# Altium schematic sheet interfaces_module.SchDoc
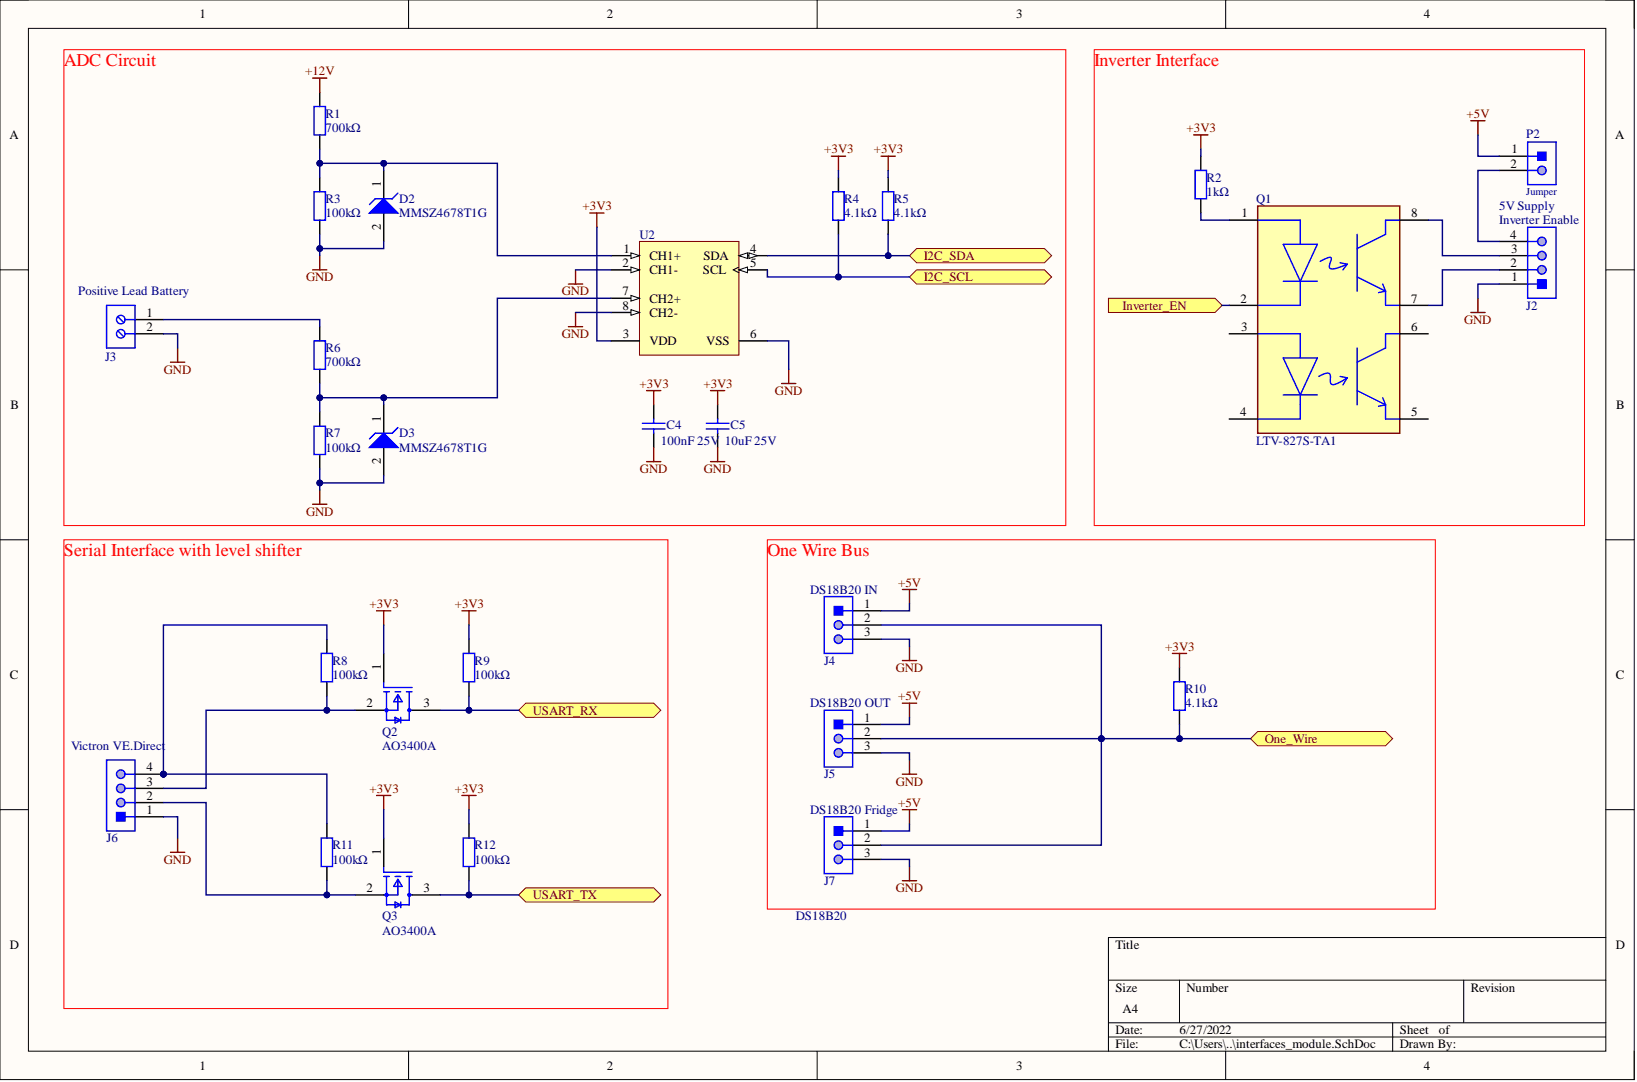
[diagram p0: interfaces_module.SchDoc]

## Parts
C4  100nF 25V  [CAPC2013X88X50ML20T25]
C5  10uF 25V  [CAPC2013X88X50ML20T25]
D2  MMSZ4678T1G  [ONSC-SOD-123-2-425-04_V]
D3  MMSZ4678T1G  [ONSC-SOD-123-2-425-04_V]
J2  JST-S4B-PH-SM4-TB-3_V  [JST-S4B-PH-SM4-TB-3_V]  (value not drawn)
J3  282836-2  [TECO-282836-2_V]  (value not drawn)
J4  JST-S3B-PH-SM4-TB-3_V  [JST-S3B-PH-SM4-TB-3_V]  (value not drawn)
J5  JST-S3B-PH-SM4-TB-3_V  [JST-S3B-PH-SM4-TB-3_V]  (value not drawn)
J6  JST-S4B-PH-SM4-TB-3_V  [JST-S4B-PH-SM4-TB-3_V]  (value not drawn)
J7  JST-S3B-PH-SM4-TB-3_V  [JST-S3B-PH-SM4-TB-3_V]  (value not drawn)
P2  Jumper  [61300211121]
Q1  LTV-827S-TA1  [FP-LTV-827S-TA1-MFG]
Q2  AO3400A  [SOT-23]
Q3  AO3400A  [SOT-23]
R1  700kΩ  [RESC2013X50X30ML20T20]
R2  1kΩ  [RESC2013X50X30ML20T20]
R3  100kΩ  [RESC2013X50X30ML20T20]
R4  4.1kΩ  [RESC2013X50X30ML20T20]
R5  4.1kΩ  [RESC2013X50X30ML20T20]
R6  700kΩ  [RESC2013X50X30ML20T20]
R7  100kΩ  [RESC2013X50X30ML20T20]
R8  100kΩ  [RESC2013X50X30ML20T20]
R9  100kΩ  [RESC2013X50X30ML20T20]
R10  4.1kΩ  [RESC2013X50X30ML20T20]
R11  100kΩ  [RESC2013X50X30ML20T20]
R12  100kΩ  [RESC2013X50X30ML20T20]
U2  (no value)  [SOIC-SN8_L]

## Ports
I2C_SCL, I2C_SDA, Inverter_EN, One_Wire, USART_RX, USART_TX

## Nets
+3V3: C4.2 C5.2 Q2.1 Q3.1 R2.1 R4.2 R5.2 R9.1 R10.2 R12.1 U2.3(VDD)
+5V: J4.1 J5.1 J7.1 P2.1
+12V: R1.1
GND: C4.1 C5.1 D2.2 D3.2 J2.1 J3.2 J4.3 J5.3 J6.1 J7.3 R3.1 R7.1 U2.2(CH1-) U2.6(VSS) U2.8(CH2-)
I2C_SCL: R4.1 U2.5(SCL) ; port I2C_SCL
I2C_SDA: R5.1 U2.4(SDA) ; port I2C_SDA
Inverter_EN: Q1.2 ; port Inverter_EN
One_Wire: J4.2 J5.2 J7.2 R10.1 ; port One_Wire
USART_RX: Q2.3 R9.2 ; port USART_RX
USART_TX: Q3.3 R12.2 ; port USART_TX
NetD2_1: D2.1 R1.2 R3.2 U2.1(CH1+)
NetD3_1: D3.1 R6.2 R7.2 U2.7(CH2+)
NetJ2_2: J2.2 Q1.7
NetJ2_3: J2.3 Q1.8
NetJ2_4: J2.4 P2.2
NetJ3_1: J3.1 R6.1
NetJ6_2: J6.2 Q3.2 R11.2
NetJ6_3: J6.3 Q2.2 R8.2
NetJ6_4: J6.4 R8.1 R11.1
NetQ1_1: Q1.1 R2.2

Not connected: Q1 3 4 5 6

## Library data of the parts
C4 C5 = Cap_0805: Capacitor; Component Kind: Standard; Library Name: Schlib.SchLib; Signal Integrity: Cap; Simulation: CAP
D2 D3 = CMP-1060-00511-1: Zener Voltage Regulator, 500 mW, 2-Pin SOD-123, Pb-Free, Tape and Reel; Manufacturer: On Semiconductor; P Max (W): 0.5; VZ Typ (V): 1.8; Component Kind: Standard; ComponentLink1Description: Manufacturer URL; ComponentLink1URL: http://www.onsemi.com/; ComponentLink2Description: Datasheet; ComponentLink2URL: http://www.onsemi.com/pub_link/Collateral/MMSZ4678T1-D.PDF; ComponentLink3Description: Package Specification; ComponentLink3URL: http://www.onsemi.com/pub_link/Collateral/425-04.PDF; DatasheetVersion: Rev. 9; Library Name: Altium Content Vault; Mounting Technology: Surface Mount; PackageDescription: 2-Pin SOD, Body 1.6 x 2.69 mm; PackageReference: SOD-123-2-425-04; PackageVersion: Rev. G, 10/2009; Packing: Tape and Reel; PartNumber: MMSZ4678T1G; RoHS: Pb-Free
J2 J6 = CONNECTOR_JST-S4B-PH-SM4: Component Kind: Standard; Description: JST-S4B-PH-SM4-TB-3_V; Library Name: integrated_library.IntLib
J3 = CMP-1684-00017-1: Female Header, Pitch 5 mm, 1 x 2 Position, Height 10 mm, Tail Length 3.5 mm, Rohs, Bulk; Manufacturer: TE Connectivity; 3D Model: 282836-2.pdf; Clamp Material - Plating: Brass-NickelPlated; Current: 13.5A; Dynamic Catalog: BuchananSeries; Featured Product: EurostyleTerminalBlockConnectors; Mating Orientation: HorizontalwithBoard; Number of Levels: 1; Other Names: 5ULV-02B  A98076; Pitch: 0.197"(5.00mm); Positions Per Level: 2; Product Training Modules: Wire-to-BoardEurostyleTerminalBlocks; Screw Thread: M3; Torque - Screw: 0.68Nm(6Lb-In); Voltage: 300V; Wire Gauge: 16-30AWG; Wire Termination: Screw-RisingCageClamp; Category: Connectors,Interconnects; Color: Green; Component Kind: Standard; Contact Material - Plating: Brass-TinPlated; Datasheet URL: http://www.te.com.cn/commerce/DocumentDelivery/DDEController?Action=showdoc&DocId=Catalog+Section%7F1308389_EUROSTYLE_TERMINAL_BLOCKS%7F0607%7Fpdf%7FEnglish%7FENG_CS_1308389_EUROSTYLE_TERMINAL_BLOCKS_0607.pdf%7F282836-2; Family: TerminalBlocks-WiretoBoard; Features: Interlocking(Side); Housing Material: Polyamide(PA66),Nylon6/6; Library Name: Altium Content Vault; Manufacturer URL: http://www.te.com; Mounting Type: ThroughHole; Package Description: Female Header, Pitch 5 mm, 1 x 2 Position, Height 10 mm, Tail Length 3.5 mm; Package Reference: THD; Packaging: Bulk; RoHS Information: 282836-2StatementofCompliance; Screw Material - Plating: Brass-NickelPlated; Series: Buchanan; Standard Package: 300
J4 J5 J7 = CONNECTOR_JST-S3B-PH-SM4: Component Kind: Standard; Description: JST-S3B-PH-SM4-TB-3_V; Library Name: integrated_library.IntLib
P2 = CMP-1712-00002-4: THT Vertical Pin Header WR-PHD, Pitch 2.54 mm, Single Row, 2 pins; Manufacturer: Wurth Elektronik; Contact Resistance: 20mOhm; Gender: Male; Pin Number: 2; Pitch: 2.54mm; Rated Current: 3A; Withstanding Voltage: 500VAC; Working Voltage: 250VAC; Component Kind: Standard; Datasheet URL: https://katalog.we-online.de/em/datasheet/6130xx11121.pdf; Library Name: Altium Content Vault; Manufacturer URL: http://www.we-online.de; Mounting Technology: THT; Operating Temperature: -40to105degC; Orientation: Vertical; Package Reference: 61300211121; Packaging: Bag; Part Number: 61300211121; Row Number: Single; Publisher: WurthElektronik
Q1 = CMP-22018-000005-1: OPTOISOLATOR 5KV 2CH TRANS 8-SMD; Collector Emitter Saturation Voltage: 200mV; Collector Emitter Voltage (VCEO): 35V; Current Transfer Ratio: 600%; Fall Time: 18000ns; Forward Current: 50mA; Forward Voltage: 1.4V; Max Power Dissipation: 200mW; Number of Channels: 2; Reverse Breakdown Voltage: 6V; Case/Package: SMD/SMT; Component Kind: Standard; Isolation Voltage: 5kV; Lead Free: Lead Free; Library Name: Altium Content Vault; Max Collector Current: 50mA; Max Input Current: 50mA; Max Operating Temperature: 110°C; Max Output Voltage: 35V; Min Operating Temperature: -30°C; Mount: Surface Mount; Number of Circuits: 2; Number of Elements: 2; Number of Pins: 8; Output Current per Channel: 50mA; Output Voltage: 35V; Package Quantity: 1000; Packaging: Tape and Reel; Power Dissipation: 200mW; Radiation Hardening: No; Rise Time: 18000ns
Q2 Q3 = MOSFET_AO3400A: MOSFET N-CH 30V 5.7A SOT23; Gate to Source Voltage (Vgs): 12V; Input Capacitance: 630pF; Max Power Dissipation: 1.4W; Number of Elements: 1; Rds On Max: 26.5mR; Case/Package: SOT-23; Component Kind: Standard; Continuous Drain Current (ID): 5.7A; Drain to Source Voltage (Vdss): 30V; Lead Free: Lead Free; Library Name: integrated_library.IntLib; Max Operating Temperature: 150°C; Min Operating Temperature: -55°C; Mount: Surface Mount; Number of Pins: 3; Packaging: Tape and Reel; Power Dissipation: 1.4W; Radiation Hardening: No
R1-R12 = Res_0805: Resistor; Component Kind: Standard; Library Name: PCB_Project.SchLib; Signal Integrity: Res; Simulation: RESISTOR
U2 = CMP-0188-01151-1: 16-Bit, Multi-Channel Delta-Sigma Analog-to-Digital Converter with I2C Interface and On-Board Reference, 8-Pin SOIC 150mil, Extended Temperature; Code_IPC: SOIC127P600-8; Code_JEDEC: MS-012; Component Kind: Standard; ComponentLink1Description: Manufacturer URL; ComponentLink1URL: http://www.microchip.com/; ComponentLink2Description: Datasheet; ComponentLink2URL: http://ww1.microchip.com/downloads/en/DeviceDoc/22226a.pdf; ComponentLink3Description: Package Specification; ComponentLink3URL: http://www.microchip.com/stellent/groups/techpub_sg/documents/packagingspec/en012702.pdf; DatasheetVersion: revA, Dec-2009; Library Name: Altium Content Vault; PackageDescription: 8-Lead Plastic Small Outline (SN) - Narrow, 3.90mm Body [SOIC]; PackageReference: SOIC-SN8; PackageVersion: revBB, Aug-2009
